# Altium schematic sheet x-IMU3-SA-Light.SchDoc
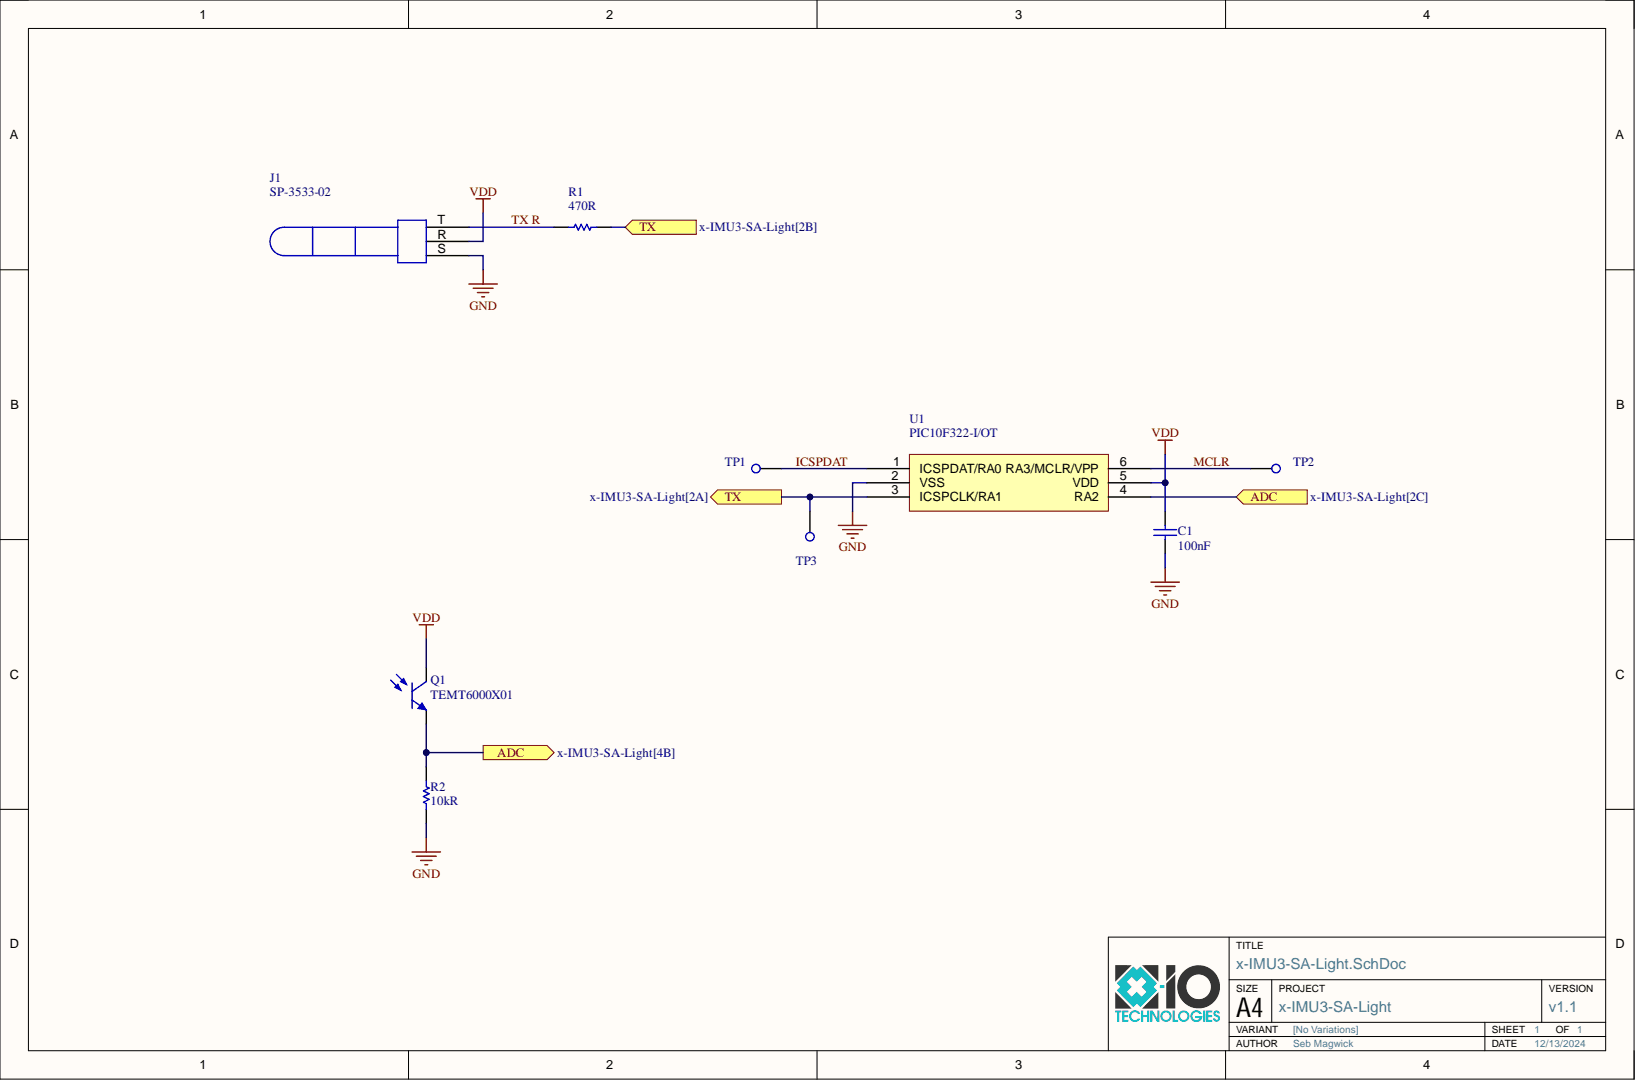
[diagram p0: x-IMU3-SA-Light.SchDoc]

## Parts
C1  100nF  [Capacitor 0402]
J1  SP-3533-02  [SP-3533-02]
Q1  TEMT6000X01  [TEMT6000X01]
R1  470R  [Resistor 0402]
R2  10kR  [Resistor 0402]
TP1  Test Point  [Test Point 1mm]  (value not drawn)
TP2  Test Point  [Test Point 1mm]  (value not drawn)
TP3  Test Point  [Test Point 1mm]  (value not drawn)
U1  PIC10F322-I/OT  [SOT-23-6]  (value not drawn)

## Ports
ADC, TX

## Nets
GND: C1.1 J1.S R2.1 U1.2(VSS)
VDD: C1.2 J1.R Q1.Collector U1.5(VDD)
ADC: Q1.Emitter R2.2 U1.4(RA2) ; port ADC
ICSPDAT: TP1.1 U1.1(ICSPDAT/RA0)
MCLR: TP2.1 U1.6(RA3/MCLR/VPP)
TX: R1.2 TP3.1 U1.3(ICSPCLK/RA1) ; port TX
TX R: J1.T R1.1

## Library data of the parts
C1 = Capacitor: Component Kind: Standard; Library Name: x-io.SchLib
R1 R2 = Resistor: Component Kind: Standard; Library Name: x-io.SchLib
J1 = SP-3533-02: Component Kind: Standard; Library Name: x-io.SchLib
Q1 = TEMT6000X01: Component Kind: Standard; Library Name: x-io.SchLib
TP1-TP3 = Test Point: Component Kind: Standard; Library Name: x-io.SchLib
U1 = PIC10F322-I/OT: Component Kind: Standard; Library Name: x-io.SchLib
